# Altium schematic sheet imp005-breakout-imp.SchDoc
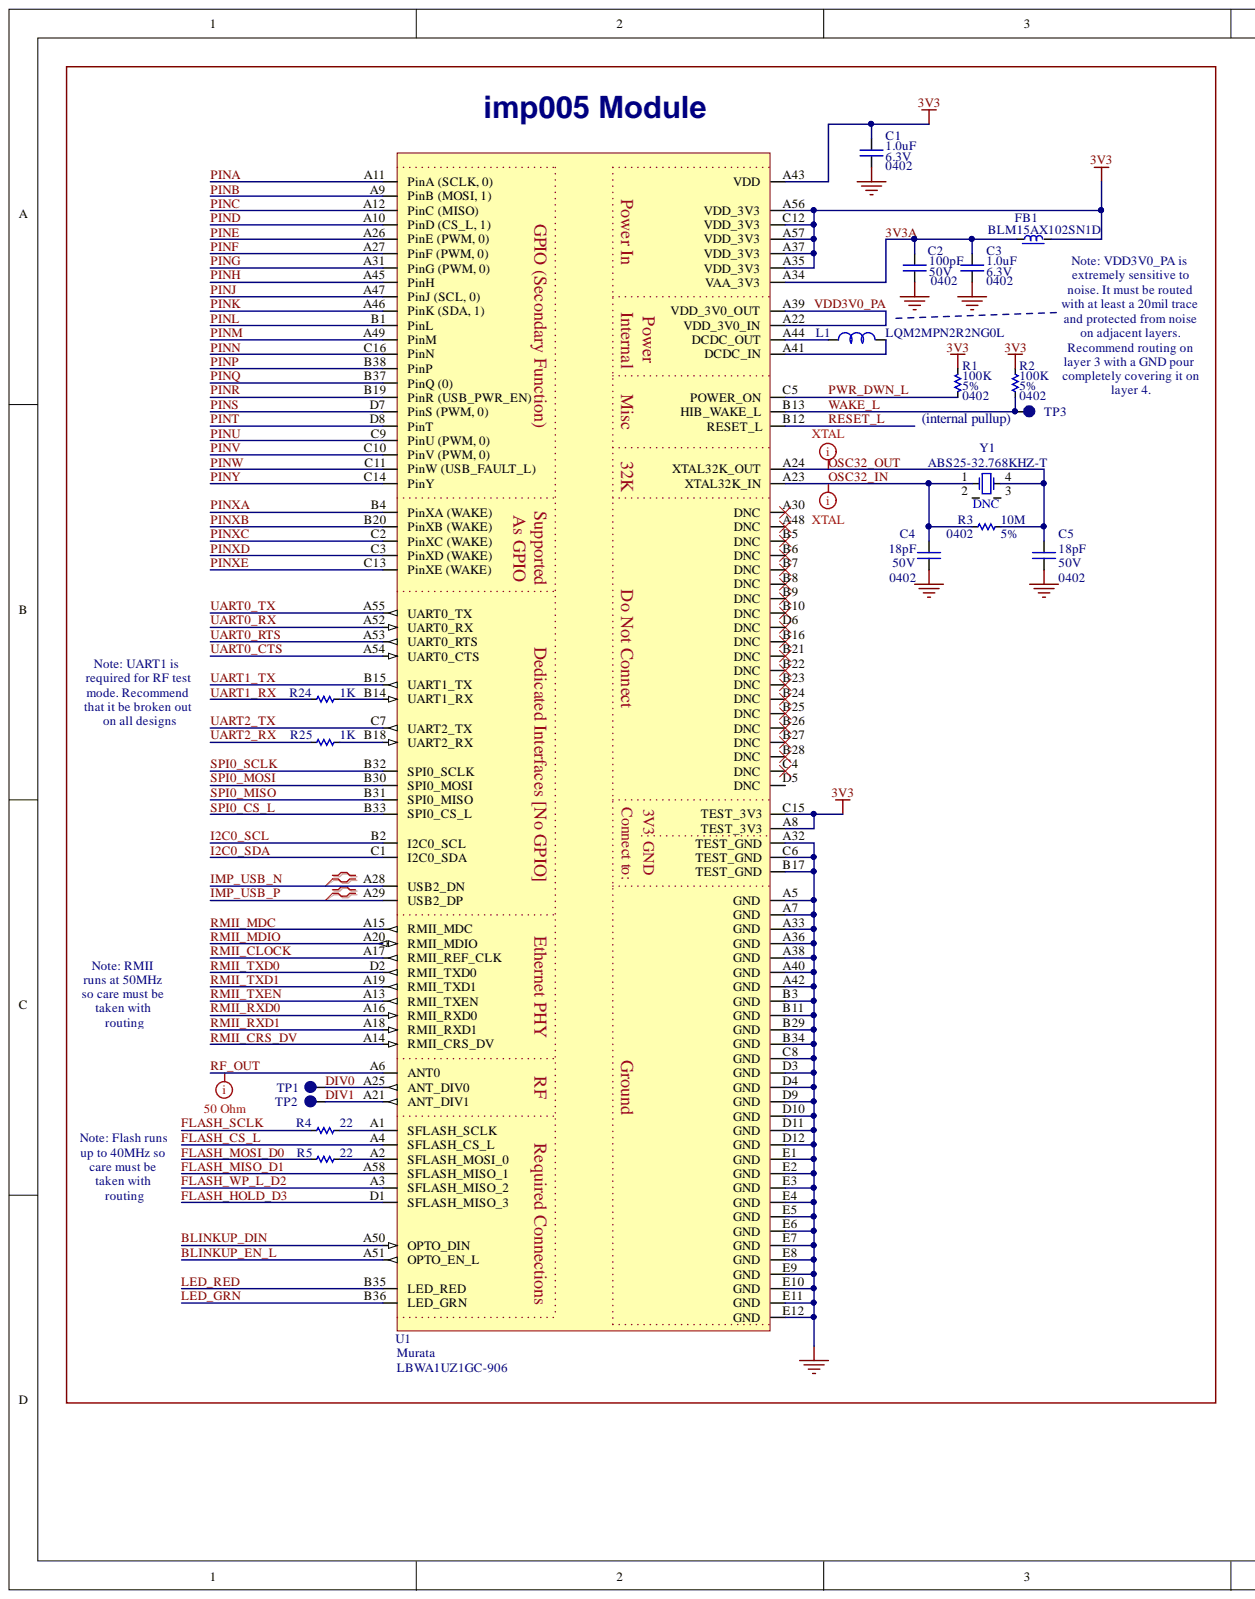
[diagram p0: imp005-breakout-imp.SchDoc, left]
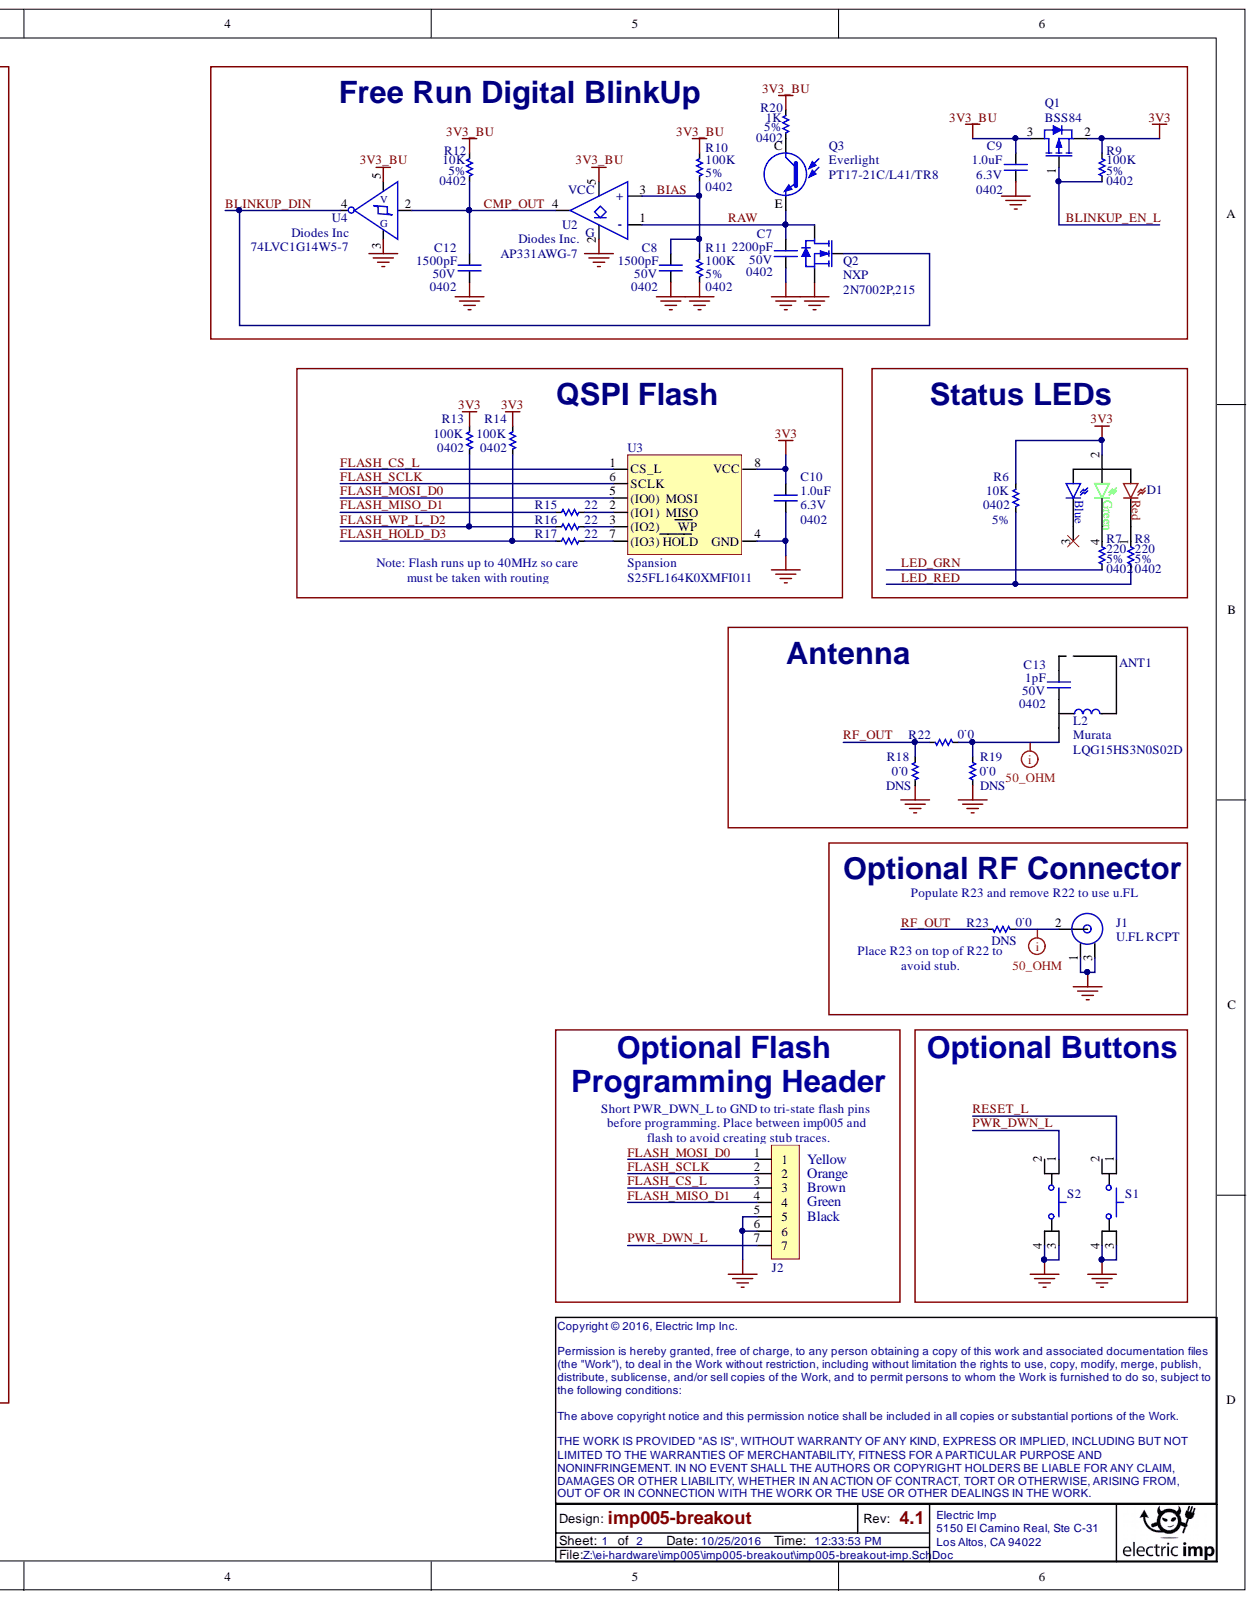
[diagram p1: imp005-breakout-imp.SchDoc, right]

## Parts
ANT1  ANT_LOOP_DUALBAND  [ANT_LOOP_DUALBAND]  (value not drawn)
C1  value 1.0uF  Package: 0402  Voltage: 6.3V  [CAPC1005X07N]  (hidden comment: Ceramic Capacitor)
C2  value 100pF  Package: 0402  Voltage: 50V  [CAPC1005X07N]  (hidden comment: Ceramic Capacitor)
C3  value 1.0uF  Package: 0402  Voltage: 6.3V  [CAPC1005X07N]  (hidden comment: Ceramic Capacitor)
C4  value 18pF  Package: 0402  Voltage: 50V  [CAPC1005X07N]  (hidden comment: Ceramic Capacitor)
C5  value 18pF  Package: 0402  Voltage: 50V  [CAPC1005X07N]  (hidden comment: Ceramic Capacitor)
C7  value 2200pF  Package: 0402  Voltage: 50V  [CAPC1005X07N]  (hidden comment: Ceramic Capacitor)
C8  value 1500pF  Package: 0402  Voltage: 50V  [CAPC1005X07N]  (hidden comment: Ceramic Capacitor)
C9  value 1.0uF  Package: 0402  Voltage: 6.3V  [CAPC1005X07N]  (hidden comment: Ceramic Capacitor)
C10  value 1.0uF  Package: 0402  Voltage: 6.3V  [CAPC1005X07N]  (hidden comment: Ceramic Capacitor)
C12  value 1500pF  Package: 0402  Voltage: 50V  [CAPC1005X07N]  (hidden comment: Ceramic Capacitor)
C13  value 1pF  Package: 0402  Voltage: 50V  [ANT_LOOP_DUALBAND_COMPONENT]  (hidden comment: Ceramic Capacitor)
D1  RGB LED  [PLCC150P350X280X210-4N]  (value not drawn)
FB1  Manufacturer Part Number: BLM15AX102SN1D  [INDC1005X06N]  (hidden comment: Ferrite Bead)
J1  U.FL RCPT  [RF_RCPT_UFL_400X400]
J2  Header  [HDR254P1X7-N]  (value not drawn)
L1  Manufacturer Part Number: LQM2MPN2R2NG0L  [INDC2016X10N]  (hidden comment: INDUCTOR)
L2  Manufacturer: Murata  [ANT_LOOP_DUALBAND_COMPONENT]  (hidden comment: INDUCTOR)
Q1  Manufacturer Part Number: BSS84  [SOT95P300X130-3N]  (hidden comment: PFET)
Q2  Manufacturer: NXP  Manufacturer Part Number: 2N7002P,215  [SOT95P300X130-3N]  (hidden comment: N-Channel MOSFET)
Q3  Manufacturer: Everlight  Manufacturer Part Number: PT17-21C/L41/TR8  [PHOTOTRANS2012X13]  (hidden comment: PHOTOTRANSISTOR)
R1  value 100K  Package: 0402  Tolerance: 5%  [RESC1005X04N]  (hidden comment: Resistor)
R2  value 100K  Package: 0402  Tolerance: 5%  [RESC1005X04N]  (hidden comment: Resistor)
R3  value 10M  Package: 0402  Tolerance: 5%  [RESC1005X04N]  (hidden comment: Resistor)
R4  value 22  [RESC1005X04N]  (hidden comment: Resistor)
R5  value 22  [RESC1005X04N]  (hidden comment: Resistor)
R6  value 10K  Package: 0402  Tolerance: 5%  [RESC1005X04N]  (hidden comment: Resistor)
R7  value 220  Package: 0402  Tolerance: 5%  [RESC1005X04N]  (hidden comment: Resistor)
R8  value 220  Package: 0402  Tolerance: 5%  [RESC1005X04N]  (hidden comment: Resistor)
R9  value 100K  Package: 0402  Tolerance: 5%  [RESC1005X04N]  (hidden comment: Resistor)
R10  value 100K  Package: 0402  Tolerance: 5%  [RESC1005X04N]  (hidden comment: Resistor)
R11  value 100K  Package: 0402  Tolerance: 5%  [RESC1005X04N]  (hidden comment: Resistor)
R12  value 10K  Package: 0402  Tolerance: 5%  [RESC1005X04N]  (hidden comment: Resistor)
R13  value 100K  Package: 0402  [RESC1005X04N]  (hidden comment: Resistor)
R14  value 100K  Package: 0402  [RESC1005X04N]  (hidden comment: Resistor)
R15  value 22  [RESC1005X04N]  (hidden comment: Resistor)
R16  value 22  [RESC1005X04N]  (hidden comment: Resistor)
R17  value 22  [RESC1005X04N]  (hidden comment: Resistor)
R18  value 0.0  BOMOPTION: DNS  [RESC1005X04N]  (hidden comment: Resistor)  (no BOM)
R19  value 0.0  BOMOPTION: DNS  [RESC1005X04N]  (hidden comment: Resistor)  (no BOM)
R20  value 1K  Package: 0402  Tolerance: 5%  [RESC1005X04N]  (hidden comment: Resistor)
R22  value 0.0  [RESC1005X04N]  (hidden comment: Resistor)
R23  value 0.0  BOMOPTION: DNS  [RESC1005X04N]  (hidden comment: Resistor)  (no BOM)
R24  value 1K  [RESC1005X04N]  (hidden comment: Resistor)
R25  value 1K  [RESC1005X04N]  (hidden comment: Resistor)
S1  Push Button  [BTN470X350X210-4N]  (value not drawn)
S2  Push Button  [BTN470X350X210-4N]  (value not drawn)
TP1  Testpoint  [TP1MM]  (value not drawn)  (no BOM)
TP2  Testpoint  [TP1MM]  (value not drawn)  (no BOM)
TP3  Testpoint  [TP1MM]  (value not drawn)  (no BOM)
U1  Manufacturer: Murata  Manufacturer Part Number: LBWA1UZ1GC-906  [BCM943907WLCSPR]  (hidden comment: imp005 module)
U2  AP331AWG  Manufacturer: Diodes Inc.  Manufacturer Part Number: AP331AWG-7  [SOT95P300X130-5N]
U3  Manufacturer: Spansion  Manufacturer Part Number: S25FL164K0XMFI011  [SOP127P790X216-8N]  (hidden comment: SPI_FLASH_QSPI)
U4  Manufacturer: Diodes Inc  Manufacturer Part Number: 74LVC1G14W5-7  [SOT95P300X130-5N]  (hidden comment: IC00205)
Y1  Manufacturer Part Number: ABS25-32.768KHZ-T  [XTAL_SOJ-4N]  (hidden comment: Crystal)

## Nets
3V3: C1.2 C10.2 D1.2 FB1.2 Q1.2 R1.1 R2.1 R6.1 R9.1 R13.1 R14.1 U1.A8(TEST_3V3) U1.A35(VDD_3V3) U1.A37(VDD_3V3) U1.A43(VDD) U1.A56(VDD_3V3) U1.A57(VDD_3V3) U1.C12(VDD_3V3) U1.C15(TEST_3V3) U3.8(VCC)
3V3_BU: C9.2 Q1.3 R10.1 R12.1 R20.1 U2.5 U4.5(V)
GND: C1.1 C2.1 C3.1 C4.1 C5.1 C7.1 C8.1 C9.1 C10.1 C12.1 J1.1 J1.3 J2.5 J2.6 Q2.2 R11.2 R18.2 R19.2 S1.3 S1.4 S2.3 S2.4 U1.A5(GND) U1.A7(GND) U1.A32(TEST_GND) U1.A33(GND) U1.A36(GND) U1.A38(GND) U1.A40(GND) U1.A42(GND) U1.B3(GND) U1.B11(GND) U1.B17(TEST_GND) U1.B29(GND) U1.B34(GND) U1.C6(TEST_GND) U1.C8(GND) U1.D3(GND) U1.D4(GND) U1.D9(GND) U1.D10(GND) U1.D11(GND) U1.D12(GND) U1.E1(GND) U1.E2(GND) U1.E3(GND) U1.E4(GND) U1.E5(GND) U1.E6(GND) U1.E7(GND) U1.E8(GND) U1.E9(GND) U1.E10(GND) U1.E11(GND) U1.E12(GND) U2.2 U3.4(GND) U4.3(G)
3V3A: C2.2 C3.2 FB1.1 U1.A34(VAA_3V3)
BIAS: C8.2 R10.2 R11.1 U2.3(+)
BLINKUP_DIN: Q2.1 U1.A50(OPTO_DIN) U4.4
BLINKUP_EN_L: Q1.1 R9.2 U1.A51(OPTO_EN_L)
CMP_OUT: C12.2 R12.2 U2.4 U4.2
DIV0: TP1.1 U1.A25(ANT_DIV0)
DIV1: TP2.1 U1.A21(ANT_DIV1)
FLASH_CS_L: J2.3 U1.A4(SFLASH_CS_L) U3.1(CS_L)
FLASH_HOLD_D3: R14.2 R17.1 U1.D1(SFLASH_MISO_3)
FLASH_MISO_D1: J2.4 R15.1 U1.A58(SFLASH_MISO_1)
FLASH_MOSI_D0: J2.1 R5.1 U3.5((IO0))
FLASH_SCLK: J2.2 R4.1 U3.6(SCLK)
FLASH_WP_L_D2: R13.2 R16.1 U1.A3(SFLASH_MISO_2)
I2C0_SCL: U1.B2(I2C0_SCL)
I2C0_SDA: U1.C1(I2C0_SDA)
IMP_USB_N: U1.A28(USB2_DN)
IMP_USB_P: U1.A29(USB2_DP)
LED_GRN: R7.2 U1.B36(LED_GRN)
LED_RED: R6.2 R8.2 U1.B35(LED_RED)
OSC32_IN: C4.2 R3.1 U1.A23(XTAL32K_IN) Y1.1
OSC32_OUT: C5.2 R3.2 U1.A24(XTAL32K_OUT) Y1.4
PINA: U1.A11(PinA_(SCLK,_0))
PINB: U1.A9(PinB_(MOSI,_1))
PINC: U1.A12(PinC_(MISO))
PIND: U1.A10(PinD_(CS_L,_1))
PINE: U1.A26(PinE_(PWM,_0))
PINF: U1.A27(PinF_(PWM,_0))
PING: U1.A31(PinG_(PWM,_0))
PINH: U1.A45(PinH)
PINJ: U1.A47(PinJ_(SCL,_0))
PINK: U1.A46(PinK_(SDA,_1))
PINL: U1.B1(PinL)
PINM: U1.A49(PinM)
PINN: U1.C16(PinN)
PINP: U1.B38(PinP)
PINQ: U1.B37(PinQ_(0))
PINR: U1.B19(PinR_(USB_PWR_EN))
PINS: U1.D7(PinS_(PWM,_0))
PINT: U1.D8(PinT)
PINU: U1.C9(PinU_(PWM,_0))
PINV: U1.C10(PinV_(PWM,_0))
PINW: U1.C11(PinW_(USB_FAULT_L))
PINXA: U1.B4(PinXA_(WAKE))
PINXB: U1.B20(PinXB_(WAKE))
PINXC: U1.C2(PinXC_(WAKE))
PINXD: U1.C3(PinXD_(WAKE))
PINXE: U1.C13(PinXE_(WAKE))
PINY: U1.C14(PinY)
PWR_DWN_L: J2.7 R1.2 S2.1 U1.C5(POWER_ON)
RAW: C7.2 Q2.3 Q3.E U2.1(-)
RESET_L: S1.1 U1.B12(RESET_L)
RF_OUT: R18.1 R22.2 R23.1 U1.A6(ANT0)
RMII_CLOCK: U1.A17(RMII_REF_CLK)
RMII_CRS_DV: U1.A14(RMII_CRS_DV)
RMII_MDC: U1.A15(RMII_MDC)
RMII_MDIO: U1.A20(RMII_MDIO)
RMII_RXD0: U1.A16(RMII_RXD0)
RMII_RXD1: U1.A18(RMII_RXD1)
RMII_TXD0: U1.D2(RMII_TXD0)
RMII_TXD1: U1.A19(RMII_TXD1)
RMII_TXEN: U1.A13(RMII_TXEN)
SPI0_CS_L: U1.B33(SPI0_CS_L)
SPI0_MISO: U1.B31(SPI0_MISO)
SPI0_MOSI: U1.B30(SPI0_MOSI)
SPI0_SCLK: U1.B32(SPI0_SCLK)
UART0_CTS: U1.A54(UART0_CTS)
UART0_RTS: U1.A53(UART0_RTS)
UART0_RX: U1.A52(UART0_RX)
UART0_TX: U1.A55(UART0_TX)
UART1_RX: R24.1
UART1_TX: U1.B15(UART1_TX)
UART2_RX: R25.1
UART2_TX: U1.C7(UART2_TX)
VDD3V0_PA: U1.A22(VDD_3V0_IN) U1.A39(VDD_3V0_OUT)
WAKE_L: R2.2 TP3.1 U1.B13(HIB_WAKE_L)
NetANT1_1: ANT1.1 R19.1 R22.1
NetANT1_2: ANT1.2 C13.1
NetANT1_3: ANT1.3 C13.2
NetANT1_4: ANT1.4 L2.2
NetANT1_5: ANT1.5 L2.1
NetD1_1: D1.1(Red) R8.1
NetD1_4: D1.4(Green) R7.1
NetJ1_2: J1.2 R23.2
NetL1_1: L1.1 U1.A44(DCDC_OUT)
NetL1_2: L1.2 U1.A41(DCDC_IN)
NetQ3_C: Q3.C R20.2
NetR4_2: R4.2 U1.A1(SFLASH_SCLK)
NetR5_2: R5.2 U1.A2(SFLASH_MOSI_0)
NetR15_2: R15.2 U3.2((IO1))
NetR16_2: R16.2 U3.3((IO2))
NetR17_2: R17.2 U3.7((IO3)_HOLD)
NetR24_2: R24.2 U1.B14(UART1_RX)
NetR25_2: R25.2 U1.B18(UART2_RX)

Not connected: D1 3(Blue); S1 2; S2 2; U1 A30(DNC) A48(DNC) B5(DNC) B6(DNC) B7(DNC) B8(DNC) B9(DNC) B10(DNC) B16(DNC) B21(DNC) B22(DNC) B23(DNC) B24(DNC) B25(DNC) B26(DNC) B27(DNC) B28(DNC) C4(DNC) D5(DNC) D6(DNC); Y1 2 3

## Library data of the parts
ANT1 = ELEC00031: Antenna; Database Table Name: misc$; Component Kind: Net Tie; Library Name: electrical.DbLib; Part Number: ELEC00031
C1 C3 C9 C10 = CAPC00005: CAP,X5R,1.0uF,10%,6.3V, 0402,#; Manufacturer: Murata; Manufacturer Part Number: GRM155R60J105KE19D; Database Table Name: capacitor$; Component Kind: Standard; Dielectric: X5R; Library Name: passives.DbLib; Manufacturer 2: Samsung; Manufacturer Part Number 2: CL05A105KQ5NNNC; Part Number: CAPC00005; Supplier 1: Digi-Key; Supplier 2: Digi-Key; Supplier Part Number 1: 490-1320-1-ND; Supplier Part Number 2: 1276-1010-1-ND; Supplier Price 1: 0.0087; Supplier Price 2: 0.009; Tolerance: 10%
  C1 C3 C10: Stock Date: 3/12/2013; Stock Quantity: 1000
C2 = CAPC00043: CAP,C0G,100pF,5%,50V,0402; Manufacturer: Yageo; Manufacturer Part Number: CC0402JRNPO9BN101; Database Table Name: capacitor$; Component Kind: Standard; Dielectric: C0G; Library Name: passives.DbLib; Manufacturer 2: Samsung; Manufacturer Part Number 2: CL05C101JB5NNNC; Part Number: CAPC00043; Stock Date: 3/12/2013; Stock Quantity: 500; Supplier 1: Digi-Key; Supplier 2: Digi-Key; Supplier Part Number 1: 311-1024-1-ND; Supplier Part Number 2: 1276-1025-1-ND; Supplier Price 1: 0.00324; Supplier Price 2: 0.00324; Tolerance: 5%
C4 C5 = CAPC00014: CAP,C0G,18pF,5%,50V,0402; Manufacturer: Yageo; Manufacturer Part Number: CC0402JRNPO9BN180; Database Table Name: capacitor$; Component Kind: Standard; Dielectric: C0G; Library Name: passives.DbLib; Manufacturer 2: Taiyo Yuden; Manufacturer Part Number 2: UMK105CG180JV-F; Part Number: CAPC00014; Stock Date: 4/19/2013; Stock Quantity: 100; Supplier 1: Digi-Key; Supplier 2: Digi-Key; Supplier Part Number 1: 311-1415-1-ND; Supplier Part Number 2: 587-1950-1-ND; Supplier Price 1: 0.00324; Supplier Price 2: 0.0054; Tolerance: 5%
C7 = CAPC00124: CAP,X7R,2200pF,10%,50V,0402; Manufacturer: Samsung; Manufacturer Part Number: CL05B222KB5NNNC; Database Table Name: capacitor$; Component Kind: Standard; Dielectric: X7R; Library Name: passives.DbLib; Manufacturer 2: Yageo; Manufacturer Part Number 2: CC0402KRX7R9BB222; Part Number: CAPC00124; Supplier 1: Digi-Key; Supplier 2: Digi-Key; Supplier Part Number 1: 1276-1054-1-ND; Supplier Part Number 2: 311-1037-1-ND; Supplier Price 1: 0.0034; Supplier Price 2: 0.0036; Tolerance: 10%
C8 C12 = CAPC00069: CAP,X7R,1500pF,10%,50V,0402; Manufacturer: Yageo; Manufacturer Part Number: CC0402KRX7R9BB152; Database Table Name: capacitor$; Component Kind: Standard; Dielectric: X7R; Library Name: passives.DbLib; Manufacturer 2: Samsung; Manufacturer Part Number 2: CL05B152KB5NNWC; Part Number: CAPC00069; Supplier 1: Digi-Key; Supplier 2: Digi-Key; Supplier Part Number 1: 311-1032-1-ND; Supplier Part Number 2: 1276-1522-1-ND; Supplier Price 1: 0.00432; Supplier Price 2: 0.00432; Tolerance: 10%
C13 = CAPC00113: CAP,C0G,1pF,±0.5pF,50V, Hi-Q (Microwave), 0402; Manufacturer: Murata; Manufacturer Part Number: GJM1555C1H1R0BB01D; Database Table Name: capacitor$; Tolerance: ±0.1pF; Component Kind: Standard; Dielectric: C0G; Library Name: passives.DbLib; Manufacturer 2: Murata; Manufacturer Part Number 2: GJM1555C1H1R0BB01J; Part Number: CAPC00113; Supplier 1: Digi-Key; Supplier 2: Digi-Key; Supplier Part Number 1: 490-6073-1-ND; Supplier Part Number 2: 490-8083-1-ND; Supplier Price 1: 0.0377; Supplier Price 2: 0.0377
D1 = LED00002: LED, RGB, 1.9/3/3V, 622/530/470nm, 71/140/36mcd, PLCC-4, DNU; Manufacturer: Cree Inc; Manufacturer Part Number: CLVBA-FKA-CAEDH8BBB7a363; Current - Test: 20mA; Database Table Name: LED$; Lens Type: Diffused, White; Millicandela Rating (min): 71mcd, 140mcd, 36mcd; Viewing Angle: 110°; Voltage - Forward (Vf) Typ: 1.9V, 3V, 3V; Wavelength - Dominant: 622nm, 530nm, 470nm; Color: RGB; Component Kind: Standard; ComponentLink1URL: http://www.cree.com/products/pdf/LEDlamps/CLVBA-FKA.pdf; Library Name: opto.DbLib; Package: PLCC-4; Part Number: LED00002; Supplier 1: Digi-Key; Supplier Part Number 1: CLVBA-FKA-CAEDH8BBB7A363CT-ND; Supplier Price 1: 0.357; Wavelength - Peak: -
FB1 = FB00007: FERR BD, 1000 Ohm @ 100Mhz, 350mA, 490 mOhm, 0402; Manufacturer: Murata; Database Table Name: ferrite$; Component Kind: Standard; ComponentLink1URL: http://www.digikey.com/product-detail/en/BLM15AX102SN1D/490-5442-1-ND/2256886; Current Rating: 350mA; DC Resistance (DCR): 490 mOhm; Impedance @ Frequency: 1000 Ohm @ 100MHz; Library Name: passives.DbLib; Package: 0402; Part Number: FB00007; Supplier 1: Digi-Key; Supplier Part Number 1: 490-5442-1-ND; Supplier Price 1: 0.02886
J1 = CON00013: Conn, Coax, U.FL, non-switch, Rcpt, 2.6 x 2.5mm, SMD; Manufacturer: Hirose; Manufacturer Part Number: U.FL-R-SMT(01); Database Table Name: misc$; Component Kind: Standard; ComponentLink1URL: http://www.digikey.com/product-detail/en/U.FL-R-SMT(10)/H9161CT-ND/2135256; Library Name: connectors.DbLib; Part Number: CON00013; Supplier 1: Digi-Key; Supplier Part Number 1: H9161CT-ND; Supplier Price 1: 0.688
J2 = HDR00007: HDR, 1x7 Pin, 2.54mm Pitch, Gold Flash, Vertical, TH; Manufacturer: TE Connectivity; Manufacturer Part Number: 3-644456-7; Connector Type: Unshrouded; Database Table Name: headers$; Gender: Male; Number of Columns: 7; Component Kind: Standard; Contact Finish: Gold Flash; Library Name: connectors.DbLib; Manufacturer 2: 4UCON; Manufacturer Part Number 2: 00809; Mounting Type: Through Hole; Number of Positions Loaded: 7; Number of Rows: 1; Orientation: Vertical; Part Number: HDR00007; Pitch: 2.54mm; Supplier 1: Digi-Key; Supplier 2: 4UCON; Supplier Part Number 1: A31117-ND; Supplier Part Number 2: 00809; Supplier Price 1: 0.24554; Supplier Price 2: 0.0162
L1 = IND00047: IND, 2.2uH, 25%, 110 mOhm, 0806, 1.2A; Manufacturer: Murata; Database Table Name: inductor$; Component Kind: Standard; ComponentLink1URL: http://www.digikey.com/product-detail/en/LQM2MPN2R2NG0L/490-7786-1-ND/4357989; Current: 1.2A; Current - Saturation: -; Current - Temperature Rise: -; DC Resistance (DCR): 110 mOhm; Library Name: passives.DbLib; Package: 0806; Part Number: IND00047; Supplier 1: Digi-Key; Supplier Part Number 1: 490-7786-1-ND; Supplier Price 1: 0.17572; Tolerance: 25%; Value: 2.2uH
L2 = IND00049: IND, 3.0nH, ±0.3nH, 170mOhm, 0402, 300mA, RF; Manufacturer Part Number: LQG15HS3N0S02D; Database Table Name: inductor$; Component Kind: Standard; ComponentLink1URL: http://www.digikey.com/product-detail/en/murata-electronics-north-america/LQG15HS3N0S02D/490-6570-1-ND/3845767; Current: 300mA; Current - Saturation: -; Current - Temperature Rise: -; DC Resistance (DCR): 170 mOhm; Library Name: passives.DbLib; Package: 0402; Part Number: IND00049; Supplier 1: Digi-Key; Supplier Part Number 1: 490-6570-1-ND; Supplier Price 1: 0.0304; Tolerance: ±0.3nH; Value: 3.0nH
Q1 = PFET00008: PFET, 50V, 130mA, 2V, SOT23; Manufacturer: Fairchild; Current - Continuous Drain (Id) @ 25° C: 0.130A; Database Table Name: PFET$; Power - Max: 360mW; Rds On (Max) @ Id, Vgs: 10 Ohm; Vgs(th) (Max) @ Id: 2V @ 1mA; Component Kind: Standard; ComponentLink1URL: http://www.digikey.com/product-detail/en/BSS84/BSS84CT-ND/244297; Drain to Source Voltage (Vdss): 50V; Library Name: discretes.DbLib; Part Number: PFET00008; Simulation: PMOS; Supplier 1: Digi-key; Supplier Part Number 1: BSS84CT-ND; Supplier Price 1: 0.0405
Q2 = NFET00002: NFET, 60V, 360mA, SOT23; Database Table Name: NFET$; Drain to Source Voltage (Vdss): 50V; Power - Max: 350mW; Rds On (Max) @ Id, Vgs: 1.6 Ohm @ 500mA, 10V; Vgs(th) (Max) @ Id: 2.4V @ 250µA; Component Kind: Standard; ComponentLink1URL: http://www.nxp.com/documents/data_sheet/2N7002P.pdf; Current - Continuous Drain (Id) @ 25° C: 360mA; Library Name: discretes.DbLib; Package: SOT23; Part Number: NFET00002; Stock Date: 5/6/2013; Stock Quantity: 77; Supplier 1: Digi-key; Supplier Part Number 1: 568-5818-1-ND; Supplier Price 1: 0.02781
Q3 = PHOTO00002: Phototrans, Clear, Top View, 940nm, 0.4V, 1mA, 0805; Database Table Name: Phototransistor$; Component Kind: Standard; ComponentLink1URL: http://www.digikey.com/product-detail/en/PT17-21C%2FL41%2FTR8/1080-1382-1-ND/2676116; Lens Type: Clear; Library Name: opto.DbLib; Package: 0805; Part Number: PHOTO00002; Supplier 1: Digi-Key; Supplier Part Number 1: 1080-1382-1-ND; Supplier Price 1: 0.07101
R1 R2 R9-R11 R13 R14 = RES00001: RES,100K,5%,0.063W,0402,#; Manufacturer: Samsung; Manufacturer Part Number: RC1005J104CS; Database Table Name: resistor$; Component Kind: Standard; Library Name: passives.DbLib; Manufacturer 2: ROHM; Manufacturer Part Number 2: MCR01MRTJ104; Part Number: RES00001; Power: 0.063W; Stock Date: 4/5/2013; Stock Quantity: 2100; Supplier 1: Digi-Key; Supplier 2: Digi-Key; Supplier Part Number 1: 1276-4424-1-ND; Supplier Part Number 2: HM100KCECT-ND; Supplier Price 1: 0.0023; Supplier Price 2: 0.00233
R3 = RES00105: RES,10M Ohm, 5%, 0.063W,0402; Manufacturer: Yageo; Manufacturer Part Number: RC0402JR-0710ML; Database Table Name: resistor$; Component Kind: Standard; Library Name: passives.DbLib; Manufacturer 2: Samsung; Manufacturer Part Number 2: RC1005J106CS; Part Number: RES00105; Power: 0.063W; Supplier 1: Digi-Key; Supplier 2: Digi-Key; Supplier Part Number 1: 311-10MJRCT-ND; Supplier Part Number 2: 1276-4470-1-ND; Supplier Price 1: 0.00213; Supplier Price 2: 0.00233
R4 R5 R15-R17 = RES00060: RES,22 Ohm,5%,0.063W,0402; Manufacturer: Yageo; Manufacturer Part Number: RC0402JR-0722RL; Database Table Name: resistor$; Component Kind: Standard; Library Name: passives.DbLib; Manufacturer 2: Stackpole; Manufacturer Part Number 2: RMCF0402JT22R0; Package: 0402; Part Number: RES00060; Power: 0.063W; Supplier 1: Digi-Key; Supplier 2: Digi-Key; Supplier Part Number 1: 311-22JRCT-ND; Supplier Part Number 2: RMCF0402JT22R0CT-ND; Supplier Price 1: 0.00237; Supplier Price 2: 0.00256; Tolerance: 5%
R6 R12 = RES00006: RES,10K,5%,0.063W,0402,#; Manufacturer: Stackpole; Manufacturer Part Number: RMCF0402JT10K0; Database Table Name: resistor$; Component Kind: Standard; Library Name: passives.DbLib; Manufacturer 2: ROHM; Manufacturer Part Number 2: MCR01MRTJ103; Part Number: RES00006; Power: 0.063W; Stock Date: 4/19/2013; Stock Quantity: 120; Supplier 1: Digi-Key; Supplier 2: Digi-Key; Supplier Part Number 1: RMCF0402JT10K0CT-ND; Supplier Part Number 2: RHM10KCECT-ND; Supplier Price 1: 0.00256; Supplier Price 2: 0.00352
R7 R8 = RES00011: RES,220 Ohm,5%,0.063W,0402; Manufacturer: Yageo; Manufacturer Part Number: RC0402JR-07220RL; Database Table Name: resistor$; Component Kind: Standard; Library Name: passives.DbLib; Manufacturer 2: Panasonic; Manufacturer Part Number 2: ERJ-2GEJ221X; Part Number: RES00011; Power: 0.063W; Supplier 1: Digi-Key; Supplier 2: Digi-Key; Supplier Part Number 1: 311-220JRCT-ND; Supplier Part Number 2: P220JCT-ND; Supplier Price 1: 0.00237; Supplier Price 2: 0.0048
R18 R19 R22 R23 = RES00019: RES,0 Ohm,0.063W,0402; Manufacturer: Yageo; Manufacturer Part Number: RC0402JR-070RL; Database Table Name: resistor$; Tolerance: 0%; Library Name: passives.DbLib; Manufacturer 2: ROHM; Manufacturer Part Number 2: MCR01MRTJ000; Package: 0402; Part Number: RES00019; Power: 0.063W; Supplier 1: Digi-Key; Supplier 2: Digi-Key; Supplier Part Number 1: 311-0.0JRCT-ND; Supplier Part Number 2: RHM0.0CECT-ND; Supplier Price 1: 0.00237; Supplier Price 2: 0.00352
  R22: Component Kind: Standard
R20 R24 R25 = RES00003: RES,1K,5%,0.063W,0402,#; Manufacturer: Yageo; Manufacturer Part Number: RC0402JR-071KL; Database Table Name: resistor$; Component Kind: Standard; Library Name: passives.DbLib; Manufacturer 2: ROHM; Manufacturer Part Number 2: MCR01MZPJ102; Part Number: RES00003; Power: 0.063W; Stock Date: 4/19/2013; Stock Quantity: 100; Supplier 1: Digi-Key; Supplier 2: Digi-Key; Supplier Part Number 1: 311-1.0KJRCT-ND; Supplier Part Number 2: RHM1.0KJCT-ND; Supplier Price 1: 0.00237; Supplier Price 2: 0.00384
  R24 R25: Package: 0402; Tolerance: 5%
S1 S2 = BTN00002: SPST- NO Momentary Button, 160g Force; Manufacturer: Panasonic; Manufacturer Part Number: EVQ-P2202M; Database Table Name: button$; Component Kind: Standard; ComponentLink1URL: http://www.digikey.com/product-detail/en/EVQ-P2202M/P12298SCT-ND/593598; Library Name: electromechanical.DbLib; Part Number: BTN00002; Supplier 1: Digi-Key; Supplier Part Number 1: P12298SCT-ND; Supplier Price 1: 0.45619
TP1-TP3 = ELEC00020: Database Table Name: misc$; Description: Testpoint; Library Name: electrical.DbLib; Part Number: ELEC00020
U1 = MOD00024: Module, blank, 2.4GHz and 5GHz WiFi, Ethernet, Murata; Database Table Name: misc$; Component Kind: Standard; Library Name: modules.DbLib; Part Number: MOD00024
U2 = IC00124: IC, Comparator, 2V-36V, Open-Drain, SOT23-5; Database Table Name: misc$; Component Kind: Standard; ComponentLink1URL: http://www.digikey.com/product-detail/en/AP331AWG-7/AP331AWGDICT-ND/2095605; Library Name: ic.DbLib; Part Number: IC00124; Supplier 1: Digi-Key; Supplier Part Number 1: AP331AWGDICT-ND; Supplier Price 1: 0.12154
U3 = IC00202: IC, SPI Flash, 64Mbit, 104MHz, QSPI, 2.7V-3.6V, SOIC-8W; Database Table Name: misc$; Component Kind: Standard; ComponentLink1URL: http://www.digikey.com/product-detail/en/S25FL164K0XMFI011/1274-1097-ND/4457674; Library Name: ic.DbLib; Part Number: IC00202; Supplier 1: Digi-Key; Supplier Part Number 1: 1274-1097-ND; Supplier Price 1: 0.64548
U4 = IC00205: IC, Logic, Schmitt Trigger Inverter, 74LVC1G14, SOT-23; Database Table Name: misc$; Component Kind: Standard; ComponentLink1URL: http://www.digikey.com/product-detail/en/74LVC1G14W5-7/74LVC1G14W5-7CT-ND/3829424; Library Name: ic.DbLib; Part Number: IC00205; Supplier 1: Digi-Key; Supplier Part Number 1: 74LVC1G14W5-7CT-ND; Supplier Price 1: 0.05786
Y1 = XTAL00016: XTAL, 32.768KHz, 20ppm, 12.5pF, 50KOhm, 8 x 3.8mm; Manufacturer: Abracon; Database Table Name: xtal$; Operating Mode: Fundamental; Component Kind: Standard; ComponentLink1URL: http://www.digikey.com/product-detail/en/ABS25-32.768KHZ-T/535-9166-1-ND/675683; Frequency: 32.768kHz; Frequency Tolerance: 20ppm; Load Capacitance: 12.5pF; Package: SOJ-4; Part Number: XTAL00016; Supplier 1: Digi-Key; Supplier Part Number 1: 35-9166-1-ND; Supplier Price 1: 0.2016; Library Name: xtalosc.DbLib
